# Altium schematic sheet LDOs.SchDoc
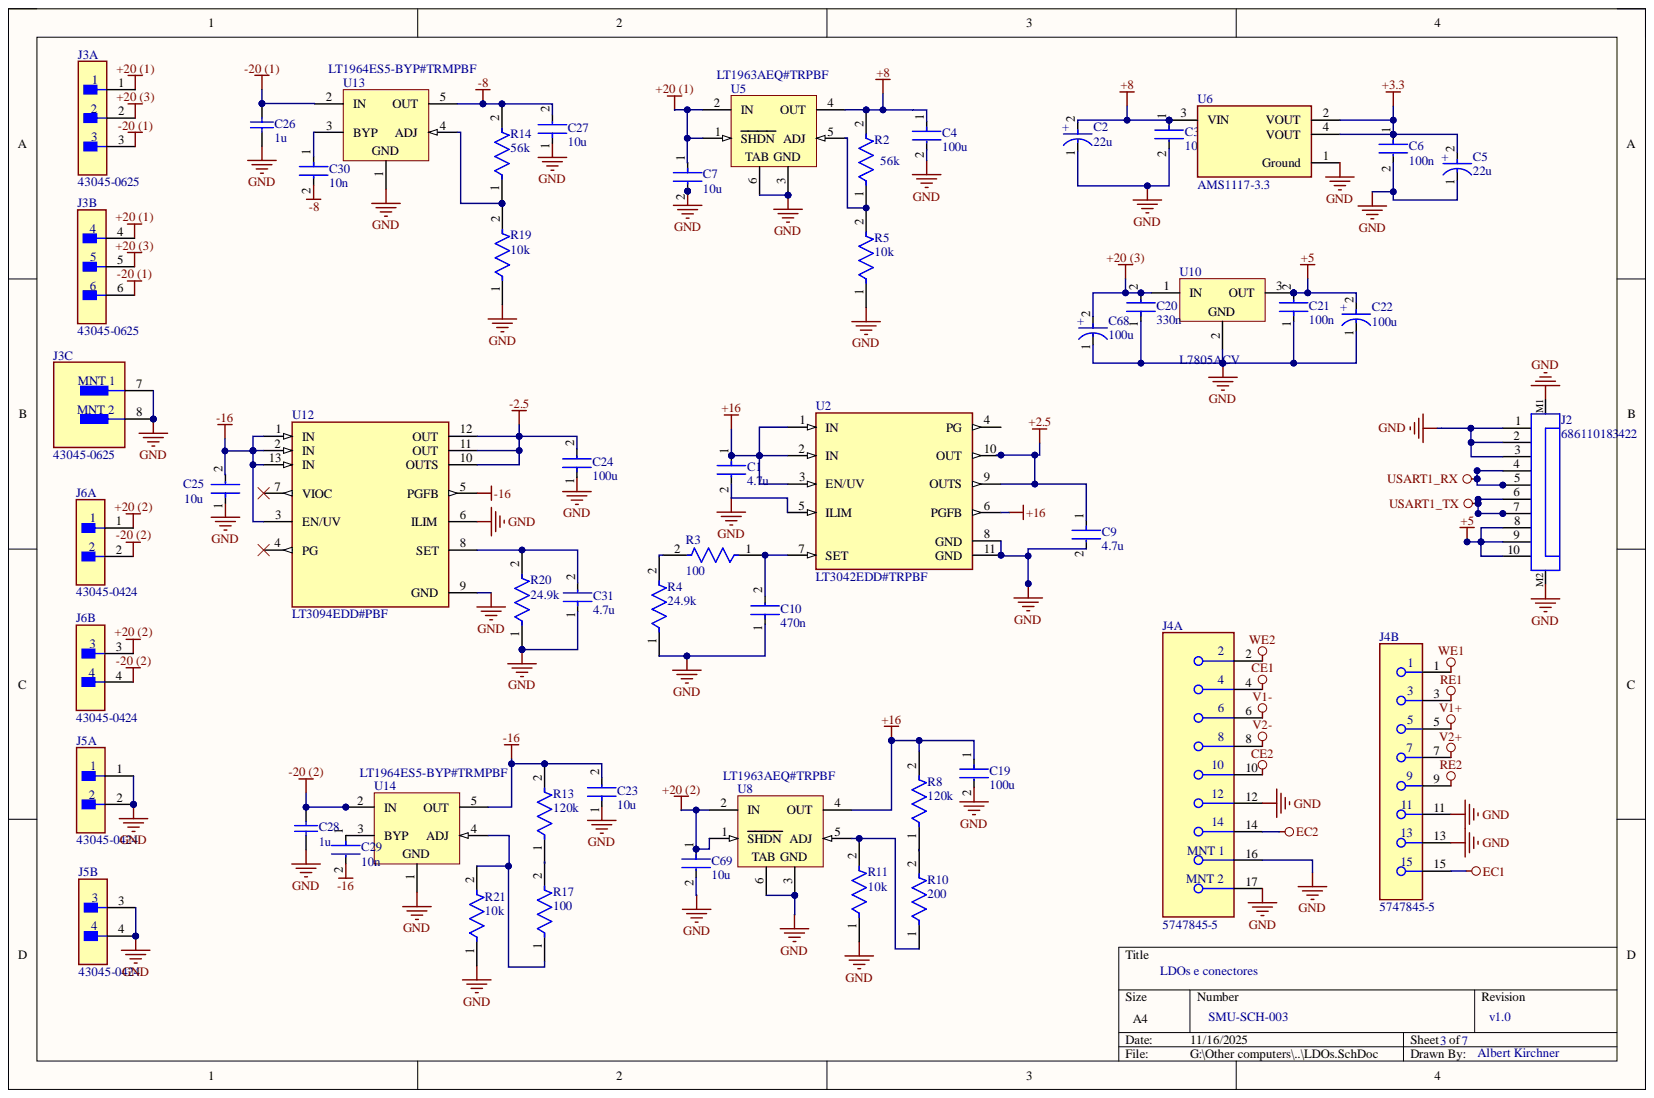
[diagram p0: LDOs.SchDoc]

## Parts
C1  4.7u  [FP-GRM31C-0_2-e0_3_0_8-IPC_C]
C2  22u  [FP-TAJA-MFG]
C3  100n  [FP-GRM31C-0_2-e0_3_0_8-IPC_C]
C4  100u  [FP-C1206C-EH-MFG]
C5  22u  [FP-TAJA-MFG]
C6  100n  [FP-GRM31C-0_2-e0_3_0_8-IPC_C]
C7  10u  [FP-C1206C-EH-MFG]
C9  4.7u  [FP-GRM31C-0_2-e0_3_0_8-IPC_C]
C10  470n  [FP-C3216-160-0_2-MFG]
C19  100u  [FP-C1206C-EH-MFG]
C20  330n  [FP-C3216-160-0_2-MFG]
C21  100n  [FP-C3216-160-0_2-MFG]
C22  100u  [FP-RAD-TH-D_10_0_5-L_12_5-MFG]
C23  10u  [FP-C3216-160-0_2-MFG]
C24  100u  [FP-C3216-160-0_2-MFG]
C25  10u  [FP-C3216-160-0_2-MFG]
C26  1u  [CAPC3216X110X50LL20T25]
C27  10u  [FP-C3216-160-0_2-MFG]
C28  1u  [CAPC3216X110X50LL20T25]
C29  10n  [FP-CC1206-1_25-MFG]
C30  10n  [FP-CC1206-1_25-MFG]
C31  4.7u  [FP-C3216-160-0_2-MFG]
C68  100u  [FP-RAD-TH-D_10_0_5-L_12_5-MFG]
C69  10u  [FP-C1206C-EH-MFG]
J2  686110183422  [686110183422]
J3  43045-0625  [FP-43045-0625-MFG]  (parts A,B,C)
J4  5747845-5  [FP-5747845-5-MFG]  (parts A,B)
J5  43045-0424  [FP-43045-0424-MFG]  (parts A,B)
J6  43045-0424  [FP-43045-0424-MFG]  (parts A,B)
R2  56k  [FP-CR1206-MFG]
R3  100  [FP-CR1206-MFG]
R4  24.9k  [FP-CR1206-MFG]
R5  10k  [FP-CR1206-MFG]
R8  120k  [FP-CR1206-MFG]
R10  200  [FP-CR1206-MFG]
R11  10k  [FP-CR1206-MFG]
R13  120k  [FP-CR1206-MFG]
R14  56k  [FP-CR1206-MFG]
R17  100  [FP-CR1206-MFG]
R19  10k  [FP-CR1206-MFG]
R20  24.9k  [FP-CR1206-MFG]
R21  10k  [FP-CR1206-MFG]
U2  LT3042EDD#TRPBF  [FP-DD-10-05-08-1699-IPC_A]
U5  LT1963AEQ#TRPBF  [LT-Q-5_L]
U6  AMS1117-3.3  [FP-AMS1117-IPC_C]
U8  LT1963AEQ#TRPBF  [LT-Q-5_L]
U10  L7805ACV  [TO220]
U12  LT3094EDD#PBF  [FP-DD-12-05-08-1725-IPC_B]
U13  LT1964ES5-BYP#TRMPBF  [LT-S5-5-TSOT-23_M]
U14  LT1964ES5-BYP#TRMPBF  [LT-S5-5-TSOT-23_M]

## Nets
+2.5: C9.1 U2.9(OUTS) U2.10(OUT)
+3.3: C5.2 C6.1 U6.2(VOUT) U6.4(VOUT)
+5: C21.2 C22.2 J2.8 J2.9 J2.10 U10.3
+8: C2.2 C3.1 C4.1 R2.2 U5.4(OUT) U6.3(VIN)
+16: C1.1 C19.1 R8.2 U2.1(IN) U2.2(IN) U2.3(EN/UV) U2.6(PGFB) U8.4(OUT)
+20 (1): C7.1 J3.1 J3.4 U5.1(SHDN) U5.2(IN)
+20 (2): C69.1 J6.1 J6.3 U8.1(SHDN) U8.2(IN)
+20 (3): C20.2 C68.2 J3.2 J3.5 U10.1
-2.5: C24.2 U12.10(OUTS) U12.11(OUT) U12.12(OUT)
-8: C27.2 C30.2 R14.2 U13.5(OUT)
-16: C23.2 C25.2 C29.2 R13.2 U12.1(IN) U12.2(IN) U12.3(EN/UV) U12.5(PGFB) U12.13(IN) U14.5(OUT)
-20 (1): C26.2 J3.3 J3.6 U13.2(IN)
-20 (2): C28.2 J6.2 J6.4 U14.2(IN)
CE1: J4.4
CE2: J4.10
EC1: J4.15
EC2: J4.14
GND: C1.2 C2.1 C3.2 C4.2 C5.1 C6.2 C7.2 C9.2 C10.1 C19.2 C20.1 C21.1 C22.1 C23.1 C24.1 C25.1 C26.1 C27.1 C28.1 C31.1 C68.1 C69.2 J2.1 J2.2 J2.3 J2.M1 J2.M2 J3.7 J3.8 J4.11 J4.12 J4.13 J4.16 J4.17 J5.1 J5.2 J5.3 J5.4 R4.1 R5.1 R11.1 R19.1 R20.1 R21.1 U2.5(ILIM) U2.8(GND) U2.11(GND) U5.3 U5.6 U6.1(Ground) U8.3 U8.6 U10.2 U12.6(ILIM) U12.9(GND) U13.1 U14.1
RE1: J4.3
RE2: J4.9
USART1_RX: J2.4 J2.5
USART1_TX: J2.6 J2.7
V1+: J4.5
V1-: J4.6
V2+: J4.7
V2-: J4.8
WE1: J4.1
WE2: J4.2
NetC10_2: C10.2 R3.1 U2.7(SET)
NetC29_1: C29.1 U14.3(BYP)
NetC30_1: C30.1 U13.3(BYP)
NetC31_2: C31.2 R20.2 U12.8(SET)
NetR2_1: R2.1 R5.2 U5.5(ADJ)
NetR3_2: R3.2 R4.2
NetR8_1: R8.1 R10.2
NetR10_1: R10.1 R11.2 U8.5(ADJ)
NetR13_1: R13.1 R17.2
NetR14_1: R14.1 R19.2 U13.4(ADJ)
NetR17_1: R17.1 R21.2 U14.4(ADJ)

Not connected: U2 4(PG); U12 4(PG) 7(VIOC)

## Library data of the parts
C1 C3 C6 C9 = CMP-1037-04923-3: Chip Multilayer Ceramic Capacitors for General Purpose, 1206, 4.7uF, X7R, 15%, 10%, 50V; Capacitance: 4.7uF; Case Code (Imperial): 1206; Case Code (Metric): 3216; Case/Package: 1206; Component Kind: Standard; Depth: 1.6mm; Dielectric: X7R; Height: 1.6mm; Lead Free: Lead Free; Length: 3.2mm; Library Name: Altium Content Vault; Material: Ceramic; Max Operating Temperature: 125°C; Min Operating Temperature: -55°C; Mount: Surface Mount; Package Quantity: 2000; Packaging: Tape and Reel; Radiation Hardening: No; REACH SVHC: No SVHC; Termination: SMD/SMT; Thickness: 0.071inch; Tolerance: 10%; Voltage: 50V; Voltage Rating: 50V; Voltage Rating (DC): 50V; Width: 0.063inch
C2 C5 = CMP-04424-000234-1: 22uF 6.3V  ±10% 3Ω 1206  SMD Tantalum Capacitor; Dissipation Factor: 6%; ESR (Equivalent Series Resistance): 3R; Leakage Current: 1.4uA; Polarity: Polar; Capacitance: 22uF; Case Code (Imperial): 1206; Case Code (Metric): 3216; Case/Package: 1206; Component Kind: Standard; Construction: Flat; Depth: 1.6mm; Dielectric Material: Tantalum; Features: General Purpose; Height: 1.6mm; Height - Seated (Max): 0.071inch; Lead Free: Lead Free; Length: 3.2mm; Library Name: Altium Content Vault; Max Operating Temperature: 125°C; Min Operating Temperature: -55°C; Mount: Surface Mount; Number of Pins: 2; Package Quantity: 1; Packaging: Tape and Reel; Radiation Hardening: No; REACH SVHC: No SVHC; RoHS Compliant: Yes; Series: TAJ; Termination: SMD/SMT; Tolerance: 10%; Voltage: 6.3V; Voltage Rating: 6.3V; Voltage Rating (DC): 6.3V; Width: 1.6mm
C4 C7 C19 C69 = CMP-1037-05005-3: CAP CER 100UF 6.3V X5R 1206; Dielectric Material: Ceramic; Dissipation Factor: 5%; Tolerance: 2%; Capacitance: 100uF; Case Code (Imperial): 1206; Case Code (Metric): 3216; Case/Package: 1206; Component Kind: Standard; Construction: Flat; Depth: 1.6mm; Dielectric: X5R; Height: 1.6mm; Lead Free: Lead Free; Length: 3.2mm; Library Name: Altium Content Vault; Max Operating Temperature: 85°C; Min Operating Temperature: -55°C; Mount: Surface Mount; Number of Pins: 2; Package Quantity: 1; Packaging: Tape and Reel; Radiation Hardening: No; REACH SVHC: No SVHC; RoHS Compliant: Yes; Series: C; Termination: SMD/SMT; Thickness: 0.071inch; Voltage: 6.3V; Voltage Rating: 6.3V; Voltage Rating (DC): 6.3V; Width: 0.063inch
C10 C20 C21 C23-C25 C27 C31 = CMP-2000-05397-3: Multilayer Ceramic Capacitors 47uF ±20% 25V X5R SMD 1206; Capacitance: 47uF; Case Code (Imperial): 1206; Case Code (Metric): 3216; Case/Package: 1206; Component Kind: Standard; Depth: 1.6mm; Dielectric: X5R; Dielectric Material: Ceramic; Height: 1.6mm; Lead Free: Lead Free; Length: 0.126inch; Library Name: Altium Content Vault; Max Operating Temperature: 85°C; Min Operating Temperature: -55°C; Mount: Surface Mount; Package Quantity: 1; Packaging: Tape and Reel; REACH SVHC: No SVHC; Resistor Type: Ceramic; RoHS Compliant: Yes; Series: C; Termination: SMD/SMT; Thickness: 0.071inch; Tolerance: 20%; Voltage: 25V; Voltage Rating: 25V; Voltage Rating (DC): 25V; Weight: 0.000571oz; Width: 0.063inch
C22 C68 = CMP-05427-000424-1: Aluminum Electrolytic Capacitors (Radial Lead Type) 100uF ±20% 63V; ESR (Equivalent Series Resistance): 63mR; Lead Diameter: 0.6mm; Life (Hours): 6000Hour; Ripple Current: 761mA; Capacitance: 100uF; Case/Package: Radial; Component Kind: Standard; Diameter: 10mm; Height: 12.5mm; Height - Seated (Max): 0.551inch; Impedance: 63mR; Lead Free: Lead Free; Lead Pitch: 5mm; Length: 12.5mm; Library Name: Altium Content Vault; Max Operating Temperature: 105°C; Min Operating Temperature: -40°C; Mount: Through Hole; Package Quantity: 1; Packaging: Tape and Reel; Radiation Hardening: No; RoHS Compliant: Yes; Series: FR; Termination: Radial; Tolerance: 20%; Voltage Rating: 63V; Voltage Rating (DC): 63V
C26 C28 = CMP-2000-06011-1: Chip Capacitor, 1 uF, +/- 10%, 50 V, -55 to 125 degC, 1206 (3216 Metric), RoHS, Tape and Reel; Brand: Kemet; Capacitance: 1uF; Capacitance - nF: 1000nF; Case Height: 1mm; Case Length: 3.2mm; Case Width: 1.6mm; Class: Class1; Dielectric: X7R; Temperature Coefficient / Code: +/-15%; Type: SMDMLCCX7R6.3-250VDCCommericalGrade; Voltage Rating: 50V; Case Code - in: 1206; Case Code - mm: 3216; Component Kind: Standard; Datasheet URL: http://www.kemet.com/docfinder?Partnumber=C1206C105K5RACTU; Datasheet Version: 02/2016; Dimensions: 1.6mmWx3.2mmLx1mmH; Factory Pack Quantity: 2500; Library Name: Altium Content Vault; Manufacturer: Kemet; Manufacturer URL: http://www.kemet.com/; Maximum Operating Temperature: +125C; Minimum Operating Temperature: -55C; Mounting Technology: SurfaceMount; Operating Temperature Range: -55Cto+125C; Package / Case: 1206(3216metric); Package Description: 2-Pin Surface Mount Device, Body 3.2 x 1.6 mm; Package Reference: 1206; Packaging: Reel; Product: GeneralTypeMLCCs; Product Category: MultilayerCeramicCapacitorsMLCC-SMD/SMT; RoHS: Details; Series: C1206C; Termination Style: SMD/SMT; Tolerance: 10%; Voltage Rating DC: 50V
C29 C30 = CMP-1037-03511-2: CAP CER 10000PF 50V X7R 1206; Manufacturer: Yageo; Manufacturer Part Number: CC1206KRX7R9BB103; Automotive Grade: NA; Capacitance: 10 nF; Material: Ceramic; Temperature Coefficient: 15%; Tolerance: 0.1; Value: 10 nF; Automotive: No; Category: Cap; Component Kind: Standard; ComponentLink2Description: Datasheet; ComponentLink2URL: http://www.yageo.com/documents/recent/UPY-NP0X7R_HV_1KV-to-3KV_11.pdf; Device Class L1: Passive Components; Device Class L2: Capacitors; Device Class L3: Ceramic Capacitors; Digikey Description: CAP CER 10000PF 50V X7R 1206; Footprint Url: http://www.yageo.com/exep/pages/download/literatures/UPY-C_GEN_24.pdf; Height: 1.45mm; Lead Free: Yes; Library Name: Altium Content Vault; Mouser Description: Multilayer Ceramic Capacitors MLCC - SMD/SMT 10nF 50V X7R 10%; Octopart: https://octopart.com/cc1206krx7r9bb103-yageo-39467800; Package: CC1206-1.25; RoHS: TRUE; Temperature: -55°C to +125°C; Temperature Characteristic: X7R; Temperature Range High: +125°C; Temperature Range Low: -55°C; Voltage Rating: 50 V
J2 = CMP-03913-81848307-1: 686110183422 WR-FPC 1.00mm SMT Horiz. Top Cont. Low Profile; Contact Resistance: 30mΩ; Current Rating: 800mA; Gender: Receptacle; Insulation Resistance: 100MΩ; Operating Supply Voltage: 50V; Pitch: 1mm; Component Kind: Standard; Lead Pitch: 1mm; Length: 11.1mm; Library Name: Altium Content Vault; Max Operating Temperature: 85°C; Min Operating Temperature: -25°C; NexarPartId: 81848307; Number of Pins: 10; Orientation: Horizontal; Packaging: Tape and Reel; RoHS: Compliant
J3 = CMP-00257-02586006-1: 43045-0625 Conn Header 6 POS 3mm Solder ST Thru-Hole 6 Terminal 1 Port Micro-Fit 3.0 Tray; Manufacturer Lifecycle Status: ACTIVE; Current Rating: 5A; Gender: Male; Glow Wire Compliant: Compliant; Number of Circuits: 6; Plating: Tin; Voltage Rating: 600V; Voltage Rating (DC): 250V; Color: Black; Component Kind: Standard; Contact Gender: Male; Contact Material: Brass; Contact Plating: Gold; Country of Origin: US; Flammability Rating: UL94 V-0; Halogen Free: Low Halogen; Height: 10.16mm; Housing Material: Thermoplastic; Lead Pitch: 3mm; Library Name: Altium Content Vault; Lifecycle Status: Production; Max Operating Temperature: 105°C; Min Operating Temperature: -40°C; NexarPartId: 2586006; Number of Contacts: 6; Number of Positions: 6; Number of Rows: 2; Orientation: Vertical; Pitch: 3mm; Radiation Hardening: No; REACH SVHC: No SVHC; RoHS: Compliant; Row Spacing: 3mm; Schedule B: 8536694040; Termination: Through Hole
J4 = CMP-03367-000001-1: CONN D-SUB RCPT 15POS R/A SOLDER; Manufacturer: TE Connectivity; Conn Gender: Female; Conn Orientation: Right Angle; Current Rating: 6A; Is Female: yes; Number Of Contacts: 15; Pitch: 2.74mm; Shielding: true; Automotive: No; Category: Conn; Component Kind: Standard; ComponentLink2Description: Datasheet; ComponentLink2URL: https://www.te.com/commerce/DocumentDelivery/DDEController?Action=showdoc&DocId=Catalog+Section%7F82068_AMPLIMITE_Right-Angle_Posted_Conn%7F0412%7Fpdf%7FEnglish%7FENG_CS_82068_AMPLIMITE_Right-Angle_Posted_Conn_0412.pdf%7F5747845-5; Contact Material: Gold,Nickel; Device Class L1: Connectors; Device Class L2: D-Sub Connectors; Device Class L3: unset; Digikey Description: CONN D-SUB RCPT 15POS R/A SOLDER; Footprint Url: https://www.te.com/commerce/DocumentDelivery/DDEController?Action=showdoc&DocId=Customer+Drawing%7F5747845%7FA2%7Fpdf%7FEnglish%7FENG_CD_5747845_A2.pdf%7F5747845-5; Height: 0.509inch; Lead Free: Yes; Library Name: Altium Content Vault; Manufacturer Part Number: 5747845-5; Mouser Description: D-Sub Standard Connectors RECP FRNT MTL SHL 15; Octopart: https://octopart.com/5747845-5-te+connectivity-39499908; Package: 5747845-5; RoHS: TRUE; Temperature: -55°C to +105°C; Temperature Range High: +105°C; Temperature Range Low: -55°C
J5 J6 = CMP-04776-001074-1: CONN HEADER VERT 4POS 3MM; Contact Resistance: 10mR; Current Rating: 5A; Gender: Male; Glow Wire Compliant: Compliant; Insulation Resistance: 1GR; Max Voltage Rating (DC): 250.25kV; Number of Circuits: 4; Voltage Rating: 600V; Voltage Rating (DC): 250V; Color: Black; Component Kind: Standard; Contact Gender: Male; Contact Material: Brass; Contact Plating: Tin; Depth: 8.77mm; Flammability Rating: UL94 V-0; Halogen Free: Low Halogen; Height: 9.91mm; Housing Color: Black; Housing Material: Thermoplastic; Length: 10.15mm; Library Name: Altium Content Vault; Max Current Rating: 5A; Max Operating Temperature: 105°C; Min Operating Temperature: -40°C; Mount: Through Hole; Number of Contacts: 4; Number of Positions: 4; Number of Rows: 2; Orientation: Vertical; Package Quantity: 2232; Packaging: Tray; Pitch: 3mm; Reach SVHC Compliant: Yes; Row Spacing: 3mm; Series: Micro-Fit 3.0; Termination: Through Hole
R2-R5 R8 R10 R11 R13 R14 R17 R19-R21 = CMP-07231-003158-1: Thick Film Chip Resistors 1206 330Ω 0.25W 1% 100ppm/°C; Max Power Dissipation: 250mW; Resistance: 330R; Voltage Rating: 200V; Case Code (Imperial): 1206; Case Code (Metric): 3216; Case/Package: 1206; Component Kind: Standard; Composition: Thick Film; Contact Plating: Tin; Depth: 1.6mm; Height: 0.6mm; Length: 3.2mm; Library Name: Altium Content Vault; Max Operating Temperature: 155°C; Military Standard: Not; Min Operating Temperature: -55°C; Mount: Surface Mount; Number of Pins: 2; Number of Terminations: 2; Package Quantity: 5000; Packaging: Tape and Reel; Power Rating: 250mW; Radiation Hardening: No; REACH SVHC: No SVHC; Temperature Coefficient: 100ppm/°C; Termination: SMD/SMT; Thickness: 610um; Tolerance: 1%; Voltage Rating (DC): 200V; Width: 1.6mm
U2 = CMP-09138-000192-1: IC REG LIN POS ADJ 200MA 10DFN; Dropout Voltage: 350mV; Min Current Limit: 220mA; Number of Regulators: 1; Output Voltage: 15V; Case/Package: DFN; Component Kind: Standard; Library Name: Altium Content Vault; Max Operating Temperature: 125°C; Min Operating Temperature: -40°C; Mount: Surface Mount; Number of Pins: 10; Output Current: 200mA; Packaging: Tape and Reel; RoHS Compliant: Yes; Series: -
U5 U8 = CMP-0476-01133-1: 1.5 A, Low Noise, Fast Transient Response LDO Regulator, 2.1 to 20 V Vin, Adjustable Vout, 5-pin DPAK (Q-5), -40 to 125 degC, Pb-Free, Tape and Reel; Manufacturer: Linear Technology; Discrete Pass Element: no; Dropout Voltage(V): 0.34; Iout(mA): 1500; Isupply(mA): 1; Noise(uV): 40; Output Voltage: Adj; PSRR(dB): 63; Shutdown Current(uA): 0.01; Vin Max(V): 20; Vin Min(V): 2.1; Vout Min(V): 1.21; Component Kind: Standard; ComponentLink1Description: Manufacturer URL; ComponentLink1URL: http://www.linear.com/; ComponentLink2Description: Datasheet; ComponentLink2URL: http://cds.linear.com/docs/en/datasheet/1963afe.pdf; ComponentLink3Description: Package Specification; ComponentLink3URL: http://cds.linear.com/docs/en/packaging/Q%20DD5%2005-08-1461%20Rev%20F.pdf; DatasheetVersion: Rev. E; Library Name: Altium Content Vault; Mounting Technology: Surface Mount; Number of Outputs: 1; PackageDescription: 5-Pin Plastic DD Pak, Body 10.2235 x 8.89 mm, Pitch 1.702 mm; PackageReference: Q-5; PackageVersion: Rev. F, 09/2011; Packing: Tape and Reel; PartNumber: LT1963AEQ#TRPBF; RoHS: TRUE; Vout Max(V): 20
U6 = CMP-209535-000001-1: LDO Voltage Regulators 1A, 3.3V; Manufacturer: Advanced Monolithic Systems; Dropout Voltage: 1.1V; Max Junction Temp: +125°C; Max Power Dissipation: 1.2W; Max Supply Voltage: 15V; Nominal Supply Current: 5mA; Number Of Outputs: 1; Output Type: Fixed; Standoff Height: 0.64mm; Automotive: No; Category: IC; Component Kind: Standard; ComponentLink2Description: Datasheet; ComponentLink2URL: http://www.advanced-monolithic.com/pdf/ds1117.pdf; Device Class L1: Integrated Circuits (ICs); Device Class L2: Power Management ICs; Device Class L3: Voltage Regulators - Linear; Height: 1.8mm; Ipc Land Pattern Name: SOT229P700X180-4; Lead Free: Yes; Library Name: Altium Content Vault; Manufacturer Part Number: AMS1117-3.3; Octopart: https://octopart.com/ams1117-3.3-advanced+monolithic+systems-25899300; Output Current: 1A; Output Voltage: 3.3V; Package: AMS1117; RoHS: TRUE; Temperature: -40°C to +125°C; Temperature Range High: +125°C; Temperature Range Low: -40°C
U10 = CMP-0244-00484-1: Positive Voltage Regulator, 5V, 3-Pin TO-220; Component Kind: Standard; ComponentLink1Description: Manufacturer URL; ComponentLink1URL: http://www.st.com; ComponentLink2Description: Datasheet; ComponentLink2URL: http://www.st.com/internet/com/TECHNICAL_RESOURCES/TECHNICAL_LITERATURE/DATASHEET/CD00000444.pdf; ComponentLink3Description: Package Specification; ComponentLink3URL: http://www.st.com/internet/com/TECHNICAL_RESOURCES/TECHNICAL_LITERATURE/PACKAGE_INFORMATION/CD00004649.pdf; DatasheetVersion: 16/11/2011; Library Name: Altium Content Vault; PackageDescription: TO, Thru-Hole, Vertical, Heatsink Mounted; 3 In-Line Leads; Pitch 2.54 mm; PackageReference: TO220; PackageVersion: ver3.0
U12 = CMP-09138-000084-1: IC REG LIN NEG ADJ 500MA 12DFN; Component Kind: Standard; Library Name: Altium Content Vault; RoHS Compliant: Yes
U13 U14 = CMP-0476-01357-1: 200 mA, Low Noise, Low Dropout Negative Micropower Regulator, -1.9 to -20 V Vin, Adjustable Vout, 5-pin SOT23 (S5-5), -40 to 125 degC, Pb-Free, Mini Tape and Reel; Manufacturer: Linear Technology; Discrete Pass Element: no; Dropout Voltage(V): 0.34; Iout(mA): 200; Isupply(mA): 0.03; Noise(uV): 30; Number of Outputs: 1; Output Voltage: Adj; PSRR(dB): 54; Shutdown Current(uA): 3; Vout Max(V): -1.22; Code_JEDEC: MO-193; Component Kind: Standard; ComponentLink1Description: Manufacturer URL; ComponentLink1URL: http://www.linear.com/; ComponentLink2Description: Datasheet; ComponentLink2URL: http://cds.linear.com/docs/en/datasheet/1964fb.pdf; ComponentLink3Description: Package Specification; ComponentLink3URL: http://cds.linear.com/docs/en/packaging/SOT_5_05-08-1635.pdf; DatasheetVersion: Rev. B; Library Name: Altium Content Vault; Mounting Technology: Surface Mount; PackageDescription: 5-Pin Plastic TSOT-23, Body 1.63 x 2.90 mm, Pitch 0.95 mm; PackageReference: S5-5; PackageVersion: Jun-10; Packing: Mini Tape and Reel; PartNumber: LT1964ES5-BYP#TRMPBF; RoHS: TRUE; Vin Max(V): -1.9; Vin Min(V): -20; Vout Min(V): -20
